# Altium schematic sheet megabuffschematic.SchDoc(megabuffschematic)
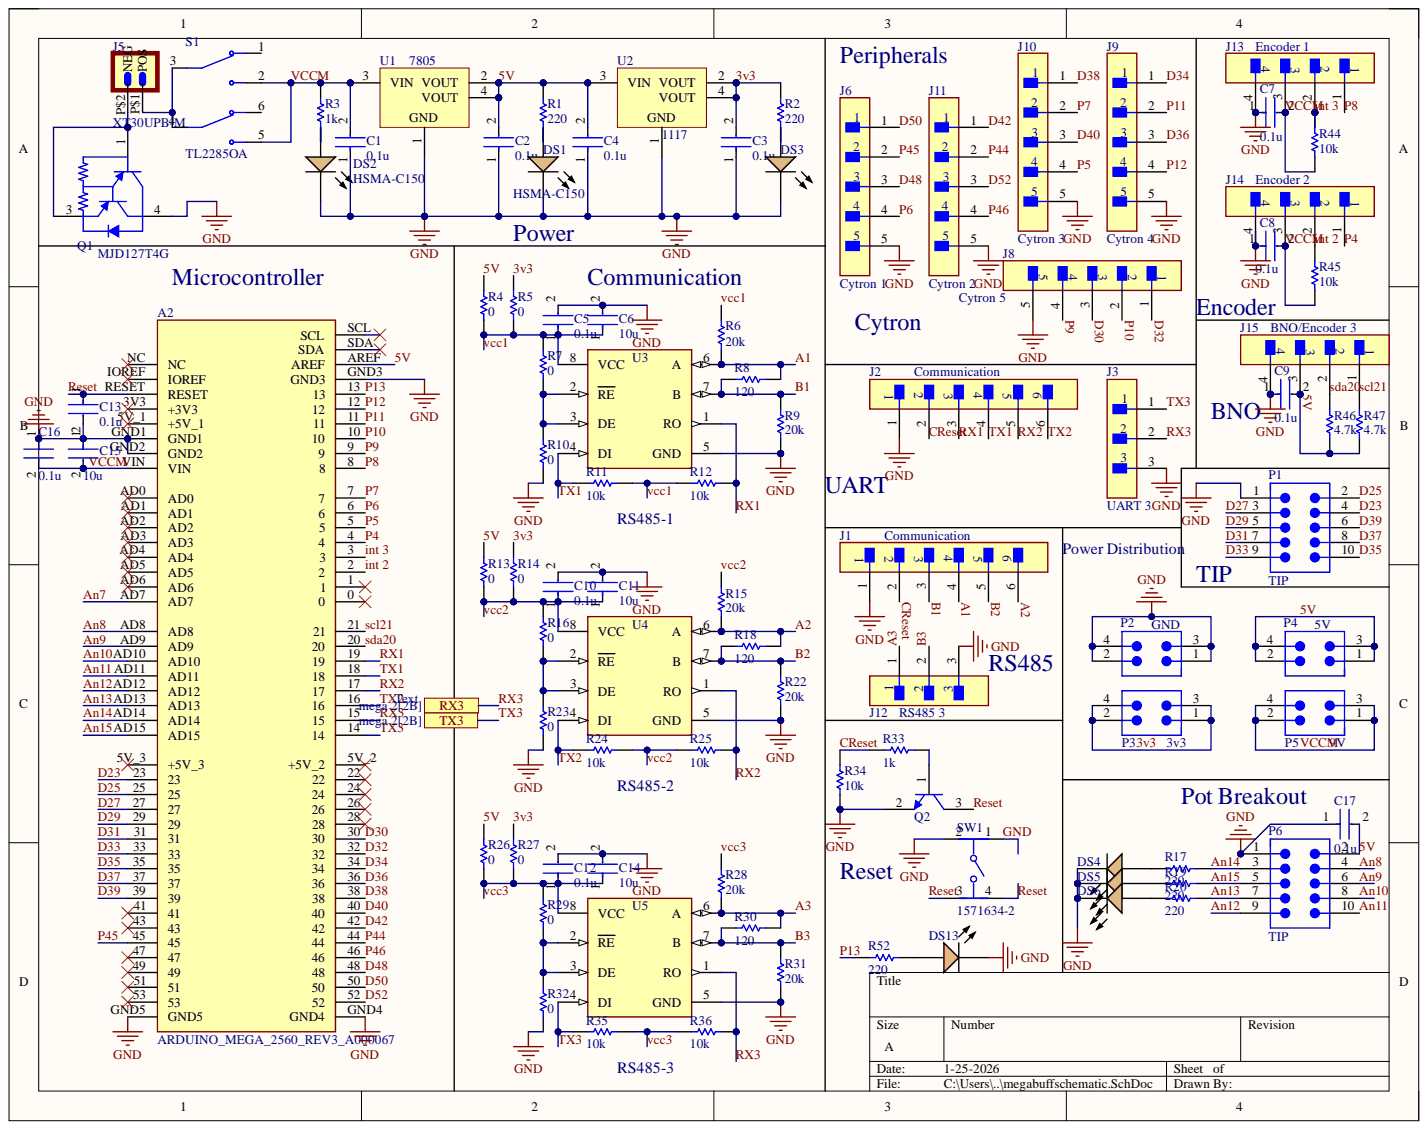
[diagram p0: megabuffschematic.SchDoc]

## Parts
A2  ARDUINO_MEGA_2560_REV3_A000067  [ARDUINO_ARDUINO_MEGA_2560_REV3_A000067]
C1  0.1u  [FP-CC0805-0_85-MFG]
C2  0.1u  [FP-CC0805-0_85-MFG]
C3  0.1u  [FP-CC0805-0_85-MFG]
C4  0.1u  [FP-CC0805-0_85-MFG]
C5  0.1u  [FP-CC0805-0_85-MFG]
C6  10u  [FP-CC0805-0_85-MFG]
C7  0.1u  [FP-CC0805-0_85-MFG]
C8  0.1u  [FP-CC0805-0_85-MFG]
C9  0.1u  [FP-CC0805-0_85-MFG]
C10  0.1u  [FP-CC0805-0_85-MFG]
C11  10u  [FP-CC0805-0_85-MFG]
C12  0.1u  [FP-CC0805-0_85-MFG]
C13  0.1u  [FP-CC0805-0_85-MFG]
C14  10u  [FP-CC0805-0_85-MFG]
C15  10u  [FP-CC0805-0_85-MFG]
C16  0.1u  [FP-CC0805-0_85-MFG]
C17  0.1u  [FP-CC0805-0_85-MFG]
DS1  HSMA-C150  [AVAG-HSMX-C150_V]
DS2  HSMA-C150  [AVAG-HSMX-C150_V]
DS3  HSMA-C150  [AVAG-HSMX-C150_V]
DS4  HSMA-C150  [AVAG-HSMX-C150_V]
DS5  HSMA-C150  [AVAG-HSMX-C150_V]
DS6  HSMA-C150  [AVAG-HSMX-C150_V]
DS13  HSMA-C150  [AVAG-HSMX-C150_V]
J1  Communication  [FP-B6B-XH-A_LF_SN-MFG]
J2  Communication  [FP-B6B-XH-A_LF_SN-MFG]
J3  UART 3  [FP-B3B-XH-A_LF_SN-MFG]
J5  XT30UPB-M  [XT30UPB-M]
J6  Cytron 1  [FP-B5B-XH-AM_LF_SN-MFG]
J8  Cytron 5  [FP-B5B-XH-AM_LF_SN-MFG]
J9  Cytron 4  [FP-B5B-XH-AM_LF_SN-MFG]
J10  Cytron 3  [FP-B5B-XH-AM_LF_SN-MFG]
J11  Cytron 2  [FP-B5B-XH-AM_LF_SN-MFG]
J12  RS485 3  [FP-B3B-XH-A_LF_SN-MFG]
J13  Encoder 1  [FP-B4B-XH-A_LF_SN-MFG]
J14  Encoder 2  [FP-B4B-XH-A_LF_SN-MFG]
J15  BNO/Encoder 3  [FP-B4B-XH-A_LF_SN-MFG]
P1  TIP  [61201021721]
P2  GND  [61300421121]
P3  3v3  [61300421121]
P4  5V  [61300421121]
P5  9V  [61300421121]
P6  TIP  [61201021721]
Q1  MJD127T4G  [ONSC-DPAK-3-369C-01_V]
Q2  BC817-25LT1G  [ONSC-SOT-23-3-318-08_V]  (value not drawn)
R1  220  [RESC2013X60X35ML10T20]
R2  220  [RESC2013X60X35ML10T20]
R3  1k  [RESC2013X60X35ML10T20]
R4  0  [RESC2013X60X35ML10T20]
R5  0  [RESC2013X60X35ML10T20]
R6  20k  [RESC2013X60X35ML10T20]
R7  0  [RESC2013X60X35ML10T20]
R8  120  [RESC2013X60X35ML10T20]
R9  20k  [RESC2013X60X35ML10T20]
R10  0  [RESC2013X60X35ML10T20]
R11  10k  [RESC2013X60X35ML10T20]
R12  10k  [RESC2013X60X35ML10T20]
R13  0  [RESC2013X60X35ML10T20]
R14  0  [RESC2013X60X35ML10T20]
R15  20k  [RESC2013X60X35ML10T20]
R16  0  [RESC2013X60X35ML10T20]
R17  220  [RESC2013X60X35ML10T20]
R18  120  [RESC2013X60X35ML10T20]
R19  220  [RESC2013X60X35ML10T20]
R20  220  [RESC2013X60X35ML10T20]
R22  20k  [RESC2013X60X35ML10T20]
R23  0  [RESC2013X60X35ML10T20]
R24  10k  [RESC2013X60X35ML10T20]
R25  10k  [RESC2013X60X35ML10T20]
R26  0  [RESC2013X60X35ML10T20]
R27  0  [RESC2013X60X35ML10T20]
R28  20k  [RESC2013X60X35ML10T20]
R29  0  [RESC2013X60X35ML10T20]
R30  120  [RESC2013X60X35ML10T20]
R31  20k  [RESC2013X60X35ML10T20]
R32  0  [RESC2013X60X35ML10T20]
R33  1k  [RESC2013X60X35ML10T20]
R34  10k  [RESC2013X60X35ML10T20]
R35  10k  [RESC2013X60X35ML10T20]
R36  10k  [RESC2013X60X35ML10T20]
R44  10k  [RESC2013X60X35ML10T20]
R45  10k  [RESC2013X60X35ML10T20]
R46  4.7k  [RESC2013X60X35ML10T20]
R47  4.7k  [RESC2013X60X35ML10T20]
R52  220  [RESC2013X60X35ML10T20]
S1  TL2285OA  [SW_TL2285OA]
SW1  1571634-2  [FP-1571634-2-MFG]
U1  7805  [SOT-223_L]
U2  1117  [SOT-223_L]
U3  MAX485ESA+T  [FP-S8-2-MFG]  (value not drawn)
U4  MAX485ESA+T  [FP-S8-2-MFG]  (value not drawn)
U5  MAX485ESA+T  [FP-S8-2-MFG]  (value not drawn)

## Ports
RX3, TX3

## Nets
3v3: C3.2 P3.1 P3.2 P3.3 P3.4 R2.2 R5.1 R14.1 R27.1 U2.2(VOUT) U2.4(VOUT)
5V: A2.AREF C2.2 C4.2 C9.2 C17.2 J15.3 P4.1 P4.2 P4.3 P4.4 P6.2 R1.2 R4.1 R13.1 R26.1 R46.1 R47.1 U1.2(VOUT) U1.4(VOUT) U2.3(VIN)
A1: J1.4 R6.2 R8.2 U3.6(A)
A2: J1.6 R15.2 R18.2 U4.6(A)
A3: J12.1 R28.2 R30.2 U5.6(A)
An7: A2.AD7
An8: A2.AD8 P6.4
An9: A2.AD9 P6.6
An10: A2.AD10 P6.8
An11: A2.AD11 P6.10
An12: A2.AD12 P6.9
An13: A2.AD13 P6.7 R20.2
An14: A2.AD14 P6.3 R17.2
An15: A2.AD15 P6.5 R19.2
B1: J1.3 R8.1 R9.1 U3.7(B)
B2: J1.5 R18.1 R22.1 U4.7(B)
B3: J12.2 R30.1 R31.1 U5.7(B)
CReset: J1.2 J2.2 R33.2 R34.1
D23: A2.23 P1.4
D25: A2.25 P1.2
D27: A2.27 P1.3
D29: A2.29 P1.5
D30: A2.30 J8.3
D31: A2.31 P1.7
D32: A2.32 J8.1
D33: A2.33 P1.9
D34: A2.34 J9.1
D35: A2.35 P1.10
D36: A2.36 J9.3
D37: A2.37 P1.8
D38: A2.38 J10.1
D39: A2.39 P1.6
D40: A2.40 J10.3
D42: A2.42 J11.1
D48: A2.48 J6.3
D50: A2.50 J6.1
D52: A2.52 J11.3
GND: A2.GND1 A2.GND2 A2.GND3 A2.GND4 A2.GND5 C1.1 C2.1 C3.1 C4.1 C5.2 C6.2 C7.1 C8.1 C9.1 C10.2 C11.2 C12.2 C13.2 C14.2 C15.1 C16.1 C17.1 DS1.2 DS2.2 DS3.2 DS4.2 DS5.2 DS6.2 DS13.2 J1.1 J2.1 J3.3 J6.5 J8.5 J9.5 J10.5 J11.5 J12.3 J13.4 J14.4 J15.4 P1.1 P2.1 P2.2 P2.3 P2.4 P6.1 Q1.4 Q2.2 R9.2 R10.2 R22.2 R23.2 R31.2 R32.2 R34.2 SW1.1 SW1.2 U1.1 U2.1 U3.5(GND) U4.5(GND) U5.5(GND)
int 2: A2.2 J14.2 R45.2
int 3: A2.3 J13.2 R44.2
P4: A2.4 J14.1
P5: A2.5 J10.4
P6: A2.6 J6.4
P7: A2.7 J10.2
P8: A2.8 J13.1
P9: A2.9 J8.4
P10: A2.10 J8.2
P11: A2.11 J9.2
P12: A2.12 J9.4
P13: A2.13 R52.2
P44: A2.44 J11.2
P45: A2.45 J6.2
P46: A2.46 J11.4
Reset: A2.RESET C13.1 Q2.3 SW1.3 SW1.4
RX1: A2.19 J2.3 R12.2 U3.1(RO)
RX2: A2.17 J2.5 R25.2 U4.1(RO)
RX3: A2.15 J3.2 R36.2 U5.1(RO) ; port RX3
scl21: A2.21 J15.1 R47.2
sda20: A2.20 J15.2 R46.2
TX1: A2.18 J2.4 R11.1 U3.4(DI)
TX2: A2.16 J2.6 R24.1 U4.4(DI)
TX3: A2.14 J3.1 R35.1 U5.4(DI) ; port TX3
vcc1: C5.1 C6.1 R4.2 R5.2 R6.1 R7.1 R11.2 R12.1 U3.8(VCC)
vcc2: C10.1 C11.1 R13.2 R14.2 R15.1 R16.1 R24.2 R25.1 U4.8(VCC)
vcc3: C12.1 C14.1 R26.2 R27.2 R28.1 R29.1 R35.2 R36.1 U5.8(VCC)
VCCM: A2.VIN C1.2 C7.2 C8.2 C15.2 C16.2 J13.3 J14.3 P5.1 P5.2 P5.3 P5.4 R3.1 R44.1 R45.1 S1.2 S1.5 U1.3(VIN)
NetDS1_1: DS1.1 R1.1
NetDS2_1: DS2.1 R3.2
NetDS3_1: DS3.1 R2.1
NetDS4_1: DS4.1 R17.1
NetDS5_1: DS5.1 R19.1
NetDS6_1: DS6.1 R20.1
NetDS13_1: DS13.1 R52.1
NetJ5_P$1: J5.P$1 S1.3 S1.4
NetJ5_P$2: J5.P$2 Q1.1 Q1.3
NetQ2_1: Q2.1 R33.1
NetR7_2: R7.2 R10.1 U3.2(RE) U3.3(DE)
NetR16_2: R16.2 R23.1 U4.2(RE) U4.3(DE)
NetR29_2: R29.2 R32.1 U5.2(RE) U5.3(DE)

Not connected: A2 0 1 3V3(+3V3) 5V_1(+5V_1) 5V_2(+5V_2) 5V_3(+5V_3) 22 24 26 28 41 43 47 49 51 53 AD0 AD1 AD2 AD3 AD4 AD5 AD6 IOREF NC SCL SDA; S1 1 6

## Library data of the parts
A2 = ARDUINO_MEGA_2560_REV3_A000067: ATmega2560 Arduino Mega2560 AVR® ATmega AVR MCU 8-Bit Embedded Evaluation Board; MP: ARDUINO MEGA 2560 REV3 | A000067; Check_prices: https://www.snapeda.com/parts/ARDUINO%20MEGA%202560%20REV3%20%7C%20A000067/Arduino/view-part/?ref=eda; Component Kind: Standard; Library Name: ARDUINO_MEGA_2560_REV3_A000067 (1).IntLib; MF: Arduino; Package: Non-Standard Arduino; SnapEDA_Link: https://www.snapeda.com/parts/ARDUINO%20MEGA%202560%20REV3%20%7C%20A000067/Arduino/view-part/?ref=snap
C1-C17 = CMP-2100-03606-3: CAP CER 0.1UF 50V X7R 0805; Manufacturer: Yageo; Manufacturer Part Number: CC0805KRX7R9BB104; Capacitance: 100 nF; Material: Ceramic; Tolerance: 0.1; Value: 100 nF; Automotive: No; Category: Cap; Component Kind: Standard; ComponentLink2Description: Datasheet; ComponentLink2URL: http://www.yageo.com/documents/recent/UPY-GPHC_Y5V_6.3V-to-50V_8.pdf; Device Class L1: Passive Components; Device Class L2: Capacitors; Device Class L3: Ceramic Capacitors; Digikey Description: CAP CER 0.1UF 50V X7R 0805; Footprint Url: http://www.yageo.com/exep/pages/download/literatures/UPY-C_GEN_24.pdf; Height: 0.95mm; Ipc Land Pattern Name: CAPC200125X85; Lead Free: Yes; Library Name: Altium Content Vault; Mouser Description: Multilayer Ceramic Capacitors MLCC - SMD/SMT 100nF 50V X7R 10%; Octopart: https://octopart.com/cc0805krx7r9bb104-yageo-192993; Package: CC0805-0.85; RoHS: TRUE; Temperature: -30°C to +85°C; Temperature Characteristic: X7R; Temperature Range High: +85°C; Temperature Range Low: -30°C; Voltage Rating: 50 V
DS1-DS6 DS13 = CMP-2000-06420-1: High Performance Chip LED, 60 mW, 25 mA, -30 to 85 degC, 2-Pin SMD, RoHS, Tape and Reel; Brand: AvagoTechnologies; Forward Current: 20mA; Forward Voltage: 1.9V; Illumination Color: Amber; LED Size: 3.2mmx1.6mmx1.1mm; Lens Color/Style: Diffused; Luminous Intensity: 90mcd; Power Rating: 60mW; Viewing Angle: 170deg; Wavelength/Color Temperature: 592nm; Component Kind: Standard; Datasheet URL: https://datasheet.ciiva.com/29280/180503-da-01-en-chip-led-hsma-c150-gelb-29280992.pdf; Datasheet Version: 03/2008; Factory Pack Quantity: 3000; Library Name: Altium Content Vault; Manufacturer: AvagoTechnologies; Manufacturer URL: http://www.avagotech.com/; Maximum Operating Temperature: +85C; Minimum Operating Temperature: -40C; Mounting Technology: SurfaceMount; Package / Case: 3.2mmx1.6mmx1.1mm; Package Description: 2-Pin Surface Mount Device, Body 3.2 x 1.6 mm; Package Reference: HSMX-C150; Packaging: Reel; Product Category: StandardLEDs-SMD; RoHS: Details
J1 J2 = CMP-17439-000076-1: CONN HEADER VERT 6POS 2.5MM; Component Kind: Standard; Library Name: Altium Content Vault
J3 J12 = CMP-17439-000014-3: CONN HEADER VERT 3POS 2.5MM; Contact Resistance: 20mR; Current Rating: 3A; Gender: Male; Insulation Resistance: 1GR; Max Voltage Rating (AC): 250V; Number of Contacts: 3; Wire/Cable Gauge: 22AWG; Color: Natural; Component Kind: Standard; Connector Type: Header; Contact Finish - Mating: TIN; Contact Material: Brass; Contact Plating: Tin; Contact Shape: Square; Contact Type: Male Pin; Depth: 5.75mm; Fastening Type: Detent; Flammability Rating: UL94 V-0; Housing Color: White; Housing Material: Polyamide; Insulation Color: Natural; Insulation Material: Nylon; Lead Free: Lead Free; Lead Pitch: 2.5mm; Length: 9.9mm; Library Name: Altium Content Vault; Max Current Rating: 3A; Max Operating Temperature: 85°C; Max Voltage Rating (DC): 250V; Min Operating Temperature: -25°C; Mount: Through Hole; Number of Positions: 3; Number of Rows: 1; Orientation: Straight; Packaging: Bulk; Pitch: 2.5mm; REACH SVHC: No SVHC; Series: XH; Termination: Solder; Voltage Rating: 250V; Voltage Rating (AC): 250V
J5 = XT30UPB-M: Socket; DC supply; XT30; male; PIN: 2; on PCBs; THT; yellow; 15A; 500V; MF: amass; Availability: Not in stock; Check_prices: https://www.snapeda.com/parts/XT30UPB-M/AMASS/view-part/?ref=eda; Component Kind: Standard; Library Name: XT30UPB-M (1).IntLib; MP: XT30UPB-M; Package: None; Price: None; SnapEDA_Link: https://www.snapeda.com/parts/XT30UPB-M/AMASS/view-part/?ref=snap
J6 J8-J11 = CMP-17439-000304-1: CONN HEADER VERT 5POS 2.5MM; Contact Resistance: 20mR; Mated Stacking Heights: 9.8mm; Max Current Rating: 3A; Max Voltage Rating (AC): 250V; Number of Positions: 5; Color: Green; Component Kind: Standard; Connector Type: Header; Contact Finish - Mating: TIN; Contact Material: Brass; Contact Plating: Copper; Contact Shape: Square; Contact Type: Male Pin; Depth: 5.75mm; Fastening Type: Detent; Flammability Rating: UL94 V-0; Housing Color: White; Housing Material: Polyamide; Insulation Color: Natural; Insulation Material: Nylon; Lead Free: Lead Free; Length: 14.9mm; Library Name: Altium Content Vault; Max Operating Temperature: 85°C; Min Operating Temperature: -25°C; Mount: Through Hole; Number of Rows: 1; Orientation: Straight; Packaging: Bulk; Pitch: 2.5mm; Series: XH; Termination: Solder; Voltage Rating: 250V
J13-J15 = CMP-17439-000250-1: CONN HEADER VERT 4POS 2.5MM; Contact Resistance: 20mR; Current Rating: 3A; Gender: Male; Insulation Resistance: 1GR; Mated Stacking Heights: 9.8mm; Max Voltage Rating (AC): 250V; Number of Contacts: 4; Wire/Cable Gauge: 22AWG; Color: Natural; Component Kind: Standard; Connector Type: Header; Contact Finish - Mating: TIN; Contact Material: Brass; Contact Plating: Tin; Contact Shape: Square; Contact Type: Male Pin; Depth: 5.75mm; Fastening Type: Detent; Flammability Rating: UL94 V-0; Housing Color: White; Housing Material: Polyamide; Insulation Color: Natural; Insulation Material: Nylon; Lead Free: Lead Free; Lead Pitch: 2.5mm; Length: 12.4mm; Library Name: Altium Content Vault; Material: Polyamide; Max Current Rating: 3A; Max Operating Temperature: 85°C; Max Voltage Rating (DC): 250V; Min Operating Temperature: -25°C; Mount: Through Hole; Number of Positions: 4; Number of Rows: 1; Orientation: Straight; Package Quantity: 500; Packaging: Bulk; Pitch: 2.5mm; REACH SVHC: No SVHC; Series: XH; Termination: Solder; Voltage Rating: 250V; Voltage Rating (AC): 250V
P1 P6 = CMP-1502-00021-2: NexarPartId: 8917787; Connector Type: Male Pin; Contact Resistance: 20mΩ; Current Rating: 3A; Gender: Male; Insulation: Insulated; Insulation Resistance: 1GΩ; Number of Contacts: 10; Operating Supply Voltage: 250V; Pitch: 2.54mm; Color: Black; Component Kind: Standard; Contact Gender: Male; Contact Material: Copper; Contact Plating: Gold; Flammability Rating: UL94 V-0; Height: 8.85mm; Housing Material: PBT; Insulation Material: PBT; Lead Pitch: 2.54mm; Length: 20.36mm; Library Name: Altium Content Vault; Max Operating Temperature: 105°C; Min Operating Temperature: -40°C; Mount: Through Hole; Number of Pins: 10; Number of Positions: 10; Number of Rows: 2; Orientation: Right Angle; REACH SVHC: Unknown; RoHS: Compliant; Row Spacing: 2.54mm; Termination: Through Hole; Voltage Rating: 250V; Voltage Rating (DC): 250V; Width: 20.36mm
P2-P5 = CMP-1502-01066-4: NexarPartId: 32855524; Connector Type: Male Pin; Contact Resistance: 20mΩ; Current Rating: 3A; Gender: Male; Number of Contacts: 4; Operating Supply Voltage: 250V; Pitch: 2.54mm; Color: Black; Component Kind: Standard; Contact Material: Copper; Contact Mating Length: 5.9944mm; Contact Plating: Gold; Height: 8.89mm; Lead Free: Lead Free; Lead Pitch: 2.54mm; Library Name: Altium Content Vault; Max Operating Temperature: 105°C; Min Operating Temperature: -40°C; Mount: Through Hole; Number of Pins: 4; Number of Positions: 4; Number of Rows: 2; Orientation: Vertical; Packaging: Bulk; REACH SVHC: Unknown; RoHS: Compliant; Row Spacing: 2.54mm; Termination: Solder; Voltage Rating: 250V
Q1 = CMP-1047-00009-1: Complementary Darlington Power Transistor, 3-Pin DPAK, Pb-Free, Tape and Reel; Manufacturer: On Semiconductor; hFE Max (k): 12; IC Continuous (A): 8; Polarity: PNP; Component Kind: Standard; ComponentLink1Description: Manufacturer URL; ComponentLink1URL: http://www.onsemi.com/; ComponentLink2Description: Datasheet; ComponentLink2URL: http://www.onsemi.com/pub_link/Collateral/MJD122-D.PDF; ComponentLink3Description: Package Specification; ComponentLink3URL: http://www.onsemi.cn/pub_link/Collateral/369C.PDF; DatasheetVersion: Rev. 13; Library Name: Altium Content Vault; Mounting Technology: Surface Mount; PackageDescription: 3-Pin Flange Mount, Body 6.54 x 6.095 mm, Pitch 2.29 mm; PackageReference: DPAK-3-369C-01; PackageVersion: Rev. E, 02/2014; Packing: Tape and Reel; PartNumber: MJD127T4G; RoHS: TRUE
Q2 = CMP-1048-00737-1: General Purpose Transistor, NPN Silicon, 3-Pin SOT-23, Pb-Free, Tape and Reel; Manufacturer: On Semiconductor; fT Min (MHz): 100; IC Continuous (A): 0.5; Component Kind: Standard; ComponentLink1Description: Manufacturer URL; ComponentLink1URL: http://www.onsemi.com/; ComponentLink2Description: Datasheet; ComponentLink2URL: http://www.onsemi.com/pub_link/Collateral/BC817-16LT1-D.PDF; ComponentLink3Description: Package Specification; ComponentLink3URL: http://www.onsemi.cn/pub_link/Collateral/318-08.PDF; DatasheetVersion: Rev. 12; Library Name: Altium Content Vault; Mounting Technology: Surface Mount; PackageDescription: 3-Pin Small Outline Transistor, Body 2.92 x 1.3 mm, Pitch 0.95 mm; PackageReference: SOT-23-3-318-08; PackageVersion: Rev. AP, 11/2009; Packing: Tape and Reel; PartNumber: BC817-25LT1G; Polarity: NPN; RoHS: TRUE
R1-R20 R22-R36 R44-R47 R52 = CMP-2100-03673-1: Chip Resistor, 0 Ohm, Jumper, +/- 5%, 0.125 W, -55 to 155 degC, 0805 (2012 Metric), RoHS, Tape and Reel; Manufacturer: Yageo; Power Rating: 125mW; Resistance: 0mOhm; Temperature Coefficient: 200ppm/degreesC; Voltage Rating: 150V; Case Code (Imperial): 0805; Case Code (Metric): 2012; Case/Package: 0805; Component Kind: Standard; Composition: ThickFilm; Contact Plating: Tin; Datasheet URL: http://www.yageo.com/NewPortal/yageodocoutput?fileName=/pdf/R-Chip/PYu-RC_Group_51_RoHS_L_5.pdf; Datasheet Version: Rev. 5, 10/2016; Depth: 1.25mm; Height: 0.5mm; Lead Free: LeadFree; Length: 2mm; Library Name: Altium Content Vault; Manufacturer URL: http://www.yageo.com/; Max Operating Temperature: 155degC; Military Standard: Not; Min Operating Temperature: -55degC; Number of Pins: 2; Package Description: 2-Pin Surface Mounted Device, Body 2 x 1.25 mm; Package Reference: 0805; Packaging: TapeandReel; Radiation Hardening: No; REACH SVHC: Unknown; RoHSCompliant: true; Termination: SMD/SMT; Tolerance: 5%; Voltage Rating (DC): 150V; Width: 1.25mm
S1 = TL2285OA: Pushbutton Switch, TL2285, DPDT, ON-(ON), Plunger for Cap, 100mA, 30VDC,PC Pin | E-Switch TL2285OA; Check_prices: https://www.snapeda.com/parts/TL2285OA/E-Switch/view-part/?ref=eda; Component Kind: Standard; Library Name: TL2285OA (1).IntLib; MF: E-Switch; MP: TL2285OA; Package: None; SnapEDA_Link: https://www.snapeda.com/parts/TL2285OA/E-Switch/view-part/?ref=snap
SW1 = CMP-03407-000008-1: SWITCH TACTILE SPST-NO 0.05A 24V; Actuator Color: Natural; Actuator Length: 0.094inch; Actuator Material: Rubber; Actuator Type: Standard; Contact Current Rating: 50mA; Illumination Type: Illuminated; Max Voltage Rating (DC): 12V; Mechanical Life: 5000000Cycles; Operating Force: 160gf; Switch Function: Off-Mom; Throw Configuration: SPST; Case/Package: SMD/SMT; Component Kind: Standard; Contact Material: Stainless Steel; Contact Plating: Silver; Current Rating: 50mA; Depth: 10mm; ELV: Compliant; Lead Free: Lead Free; Length: 6.2mm; Library Name: Altium Content Vault; Max Current Rating: 50mA; Max Operating Temperature: 85°C; Min Operating Temperature: -40°C; Mount: Surface Mount; Orientation: Straight; Package Quantity: 1000; Packaging: Tape and Reel; Radiation Hardening: No; RoHS Compliant: Yes; Termination: Gull Wing; Type: Switch; Voltage Rating (DC): 12V
U1 U2 = CMP-0244-00312-1: Fixed Low Drop Positive Voltage Regulator, 5V, 4-Pin SOT-223, Tape and Reel; Component Kind: Standard; ComponentLink1Description: Manufacturer URL; ComponentLink1URL: http://www.st.com; ComponentLink2Description: Datasheet; ComponentLink2URL: http://www.st.com/internet/com/TECHNICAL_RESOURCES/TECHNICAL_LITERATURE/DATASHEET/CD00000544.pdf; DatasheetVersion: 1/11/2011; Library Name: Altium Content Vault; PackageDescription: 4-Pin SOT-223 Package, 6.5 x 3.5 mm Body, 2.3 mm Pitch, 7 mm Lead Span; PackageReference: SOT-223; PackageVersion: 2010
U3-U5 = CMP-05679-000009-1: IC TRANSCEIVER HALF 1/1 8SOIC; Manufacturer: Maxim Integrated; Data Rate: 2.5Mbits/s; Interface: RS-485,RS-422; Max Supply Voltage: 5.25V; Min Supply Voltage: 4.75V; Nominal Supply Current: 0.5mA; Number Of Receivers: 1; Simplex Duplex: Half-Duplex; Standoff Height: 0.1mm; Automotive: No; Category: IC; Component Kind: Standard; ComponentLink2Description: Datasheet; ComponentLink2URL: https://datasheets.maximintegrated.com/en/ds/MAX1487-MAX491.pdf; Device Class L1: Integrated Circuits (ICs); Device Class L2: Interface ICs; Device Class L3: RS-232 / RS-422 / RS-485 Interface ICs; Digikey Description: IC TRANSCEIVER HALF 1/1 8SOIC; Footprint Url: https://pdfserv.maximintegrated.com/land_patterns/90-0096.PDF; Height: 1.75mm; Ipc Land Pattern Name: SOIC127P600X155-8; Lead Free: Yes; Library Name: Altium Content Vault; Manufacturer Part Number: MAX485ESA+T; Mouser Description: RS-422/RS-485 Interface IC RS-485/RS-422 Transceiver; Number Of Transmitters: 1; Octopart: https://octopart.com/max485esa%2Bt-maxim+integrated-40011239; Package: S8-2; RoHS: TRUE; Temperature: -40°C to +85°C; Temperature Range High: +85°C; Temperature Range Low: -40°C
